# Altium schematic sheet Headers.SchDoc(Headers)
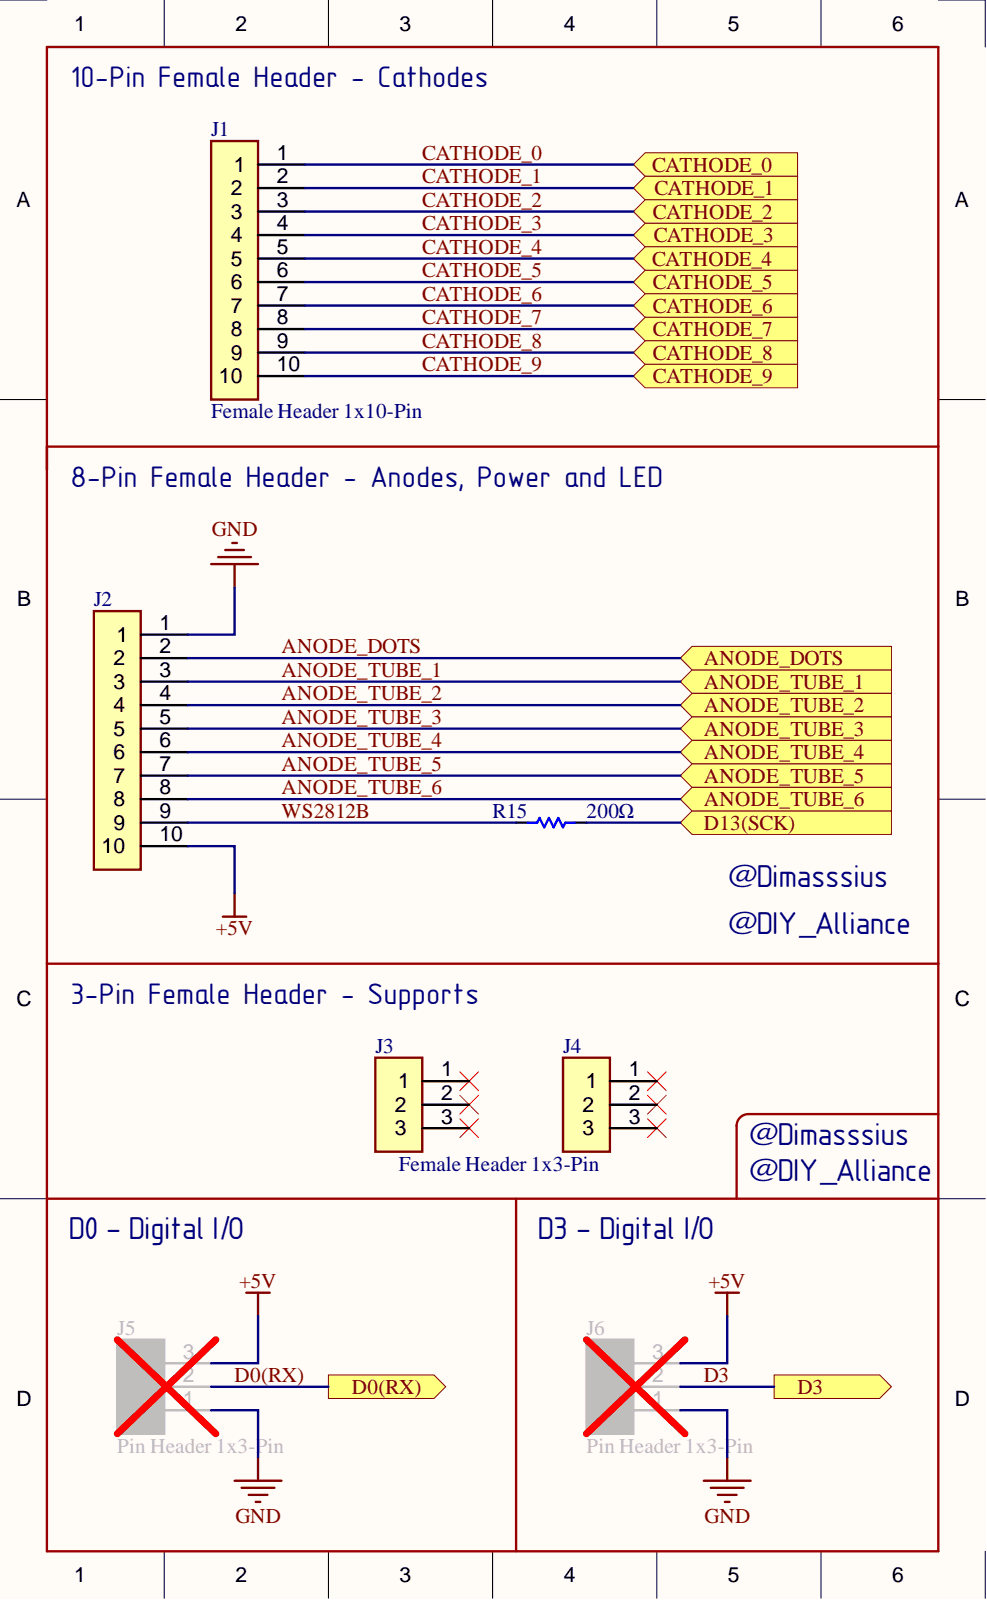
[diagram p0: Headers.SchDoc]

## Parts
J1  Female Header 1x10-Pin  [HEADER_FEMALE_10PIN]
J2  Female Header 1x10-Pin  [HEADER_FEMALE_10PIN]
J3  Female Header 1x3-Pin  [HEADER_FEMALE_3PIN]
J4  Female Header 1x3-Pin  [HEADER_FEMALE_3PIN]
J5  Pin Header 1x3-Pin  [HEADER_PIN_3PIN]
J6  Pin Header 1x3-Pin  [HEADER_PIN_3PIN]
R15  200Ω  [RES_THD/SMD_R0603_R0402_200OHM_THD]
R15
R15

## Ports
ANODE_DOTS, ANODE_TUBE_1, ANODE_TUBE_2, ANODE_TUBE_3, ANODE_TUBE_4, ANODE_TUBE_5, ANODE_TUBE_6, CATHODE_0, CATHODE_1, CATHODE_2, CATHODE_3, CATHODE_4, CATHODE_5, CATHODE_6, CATHODE_7, CATHODE_8, CATHODE_9, D0(RX), D3, D13(SCK)

## Nets
+5V: J2.10 J5.3 J6.3
GND: J2.1 J5.1 J6.1
ANODE_DOTS: J2.2 ; port ANODE_DOTS
ANODE_TUBE_1: J2.3 ; port ANODE_TUBE_1
ANODE_TUBE_2: J2.4 ; port ANODE_TUBE_2
ANODE_TUBE_3: J2.5 ; port ANODE_TUBE_3
ANODE_TUBE_4: J2.6 ; port ANODE_TUBE_4
ANODE_TUBE_5: J2.7 ; port ANODE_TUBE_5
ANODE_TUBE_6: J2.8 ; port ANODE_TUBE_6
CATHODE_0: J1.1 ; port CATHODE_0
CATHODE_1: J1.2 ; port CATHODE_1
CATHODE_2: J1.3 ; port CATHODE_2
CATHODE_3: J1.4 ; port CATHODE_3
CATHODE_4: J1.5 ; port CATHODE_4
CATHODE_5: J1.6 ; port CATHODE_5
CATHODE_6: J1.7 ; port CATHODE_6
CATHODE_7: J1.8 ; port CATHODE_7
CATHODE_8: J1.9 ; port CATHODE_8
CATHODE_9: J1.10 ; port CATHODE_9
D0(RX): J5.2 ; port D0(RX)
D3: J6.2 ; port D3
D13(SCK): R15.2 ; port D13(SCK)
WS2812B: J2.9 R15.1

Not connected: J3 1 2 3; J4 1 2 3

## Library data of the parts
J1 J2 = HDR F 1X10 2.54MM: Female Header, 1x10-Pin, 10P, P=2.54mm, Direct Insert, H:8.5mm, W:2.54mm, D:3.2mm, Single Row, Black Brass, Top Square, Hole Plugin, 3A, 20mΩ, 1000V, -40℃~+105℃, Female Headers, ROHS; Component Kind: Standard; Library Name: SamacSys.SchLib; Value: Female Header 1x10-Pin
J3 J4 = HDR F 1X3 2.54MM: Female Header, 1x3-Pin, 3P, P=2.54mm, Direct Insert, H:8.5mm, W:2.54mm, D:3.2mm, Single Row, Black Brass, Top Square, Hole Plugin, 3A, 20mΩ, 1000V, -40℃~+105℃, Female Headers, ROHS; Component Kind: Standard; Library Name: SamacSys.SchLib; Value: Female Header 1x3-Pin
J5 J6 = HDR P 1X3 2.54MM: Pin Header, 1x3-Pin, 3P, Straight Policy, MH:6mm, BH:2.5mm, PL:3mm, Single Row, Black Brass, Top Square, Plugin, 3A, 20mΩ, 250V, -40℃~+105℃, Pin Headers, ROHS; Component Kind: Standard; Library Name: SamacSys.SchLib; Value: Pin Header 1x3-Pin
R15 = RES_THD/SMD_200Ω_R0603_R0402_THD: Resistor, Metal Film Resistor, Through Hole, D2.3xL6.5mm, 200Ω, ±1%, 250mW, Through Hole Resistors, ROHS; Component Kind: Standard; Library Name: Resistors.SchLib; Value: 200Ω
